# Altium schematic sheet SPK_P.SchDoc
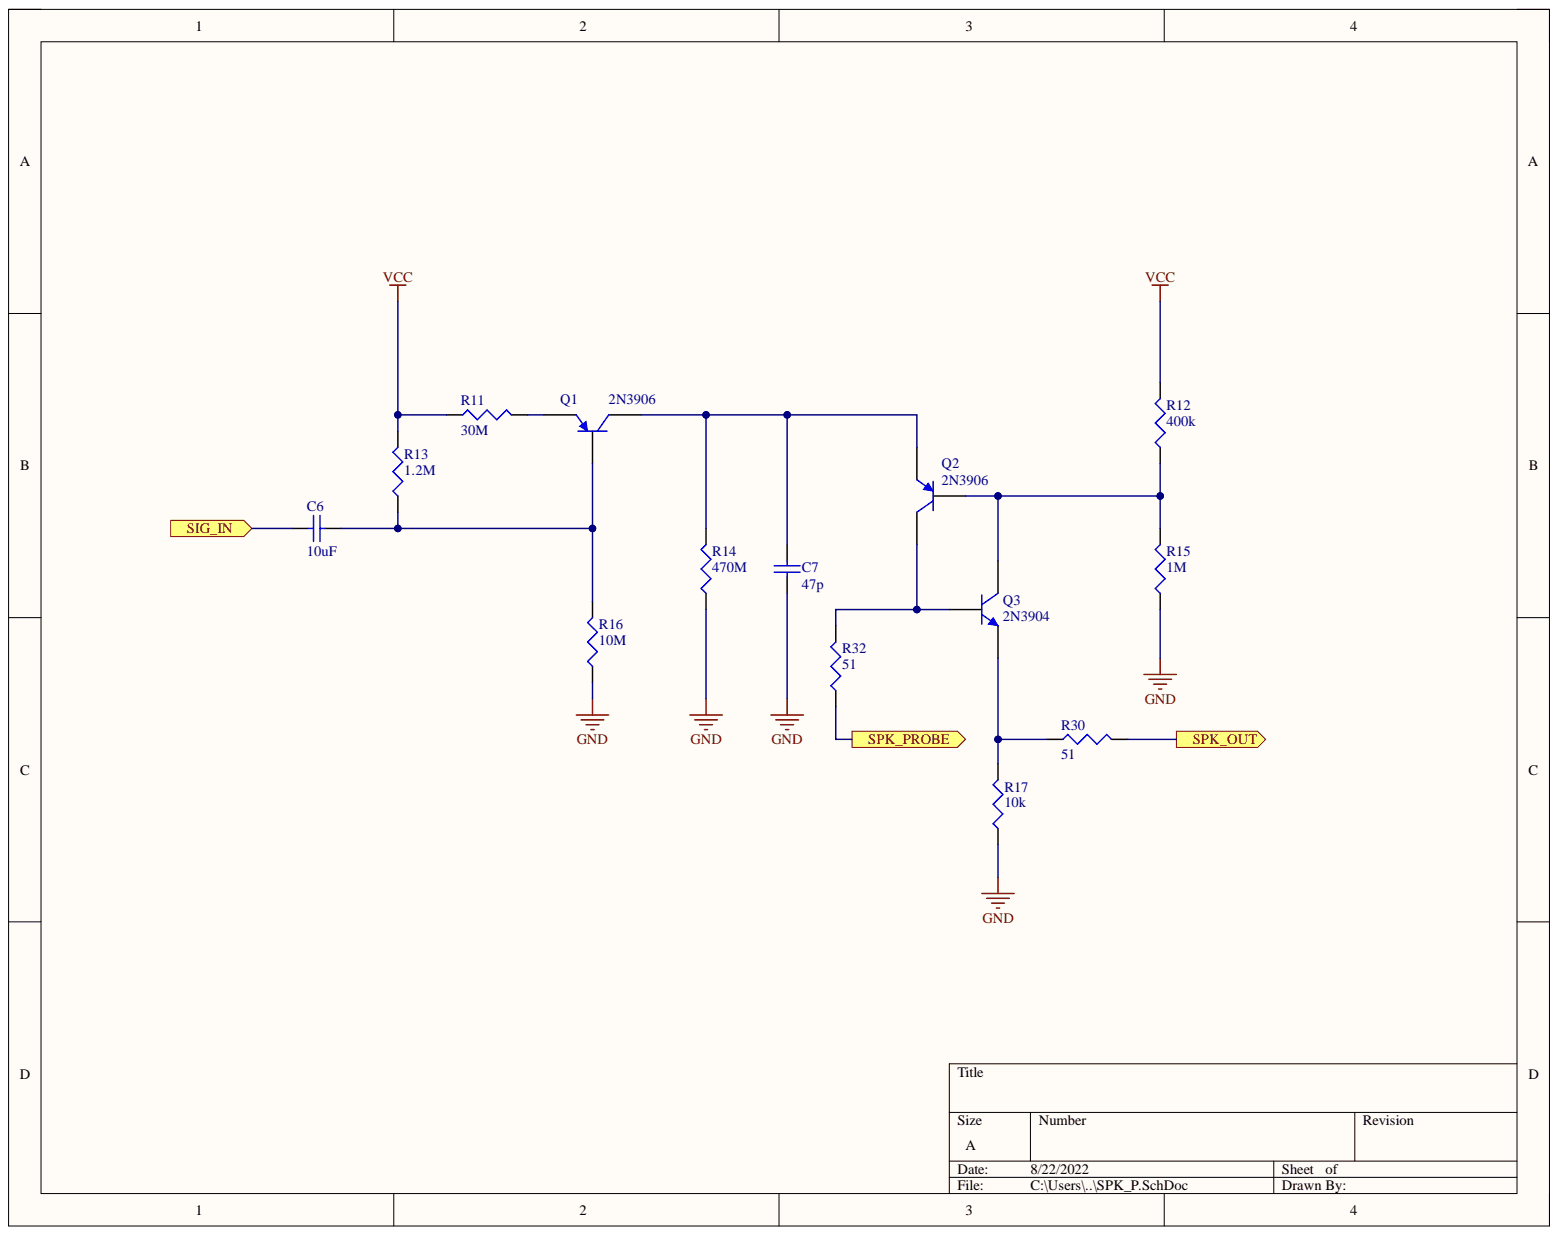
[diagram p0: SPK_P.SchDoc]

## Parts
C6  10uF  [SMD-0603]
C7  47p  [SMD-0603]
Q1  2N3906  [TO-92A]
Q2  2N3906  [TO-92A]
Q3  2N3904  [TO-92A]
R11  30M  [SMD-0603]
R12  400k  [SMD-0603]
R13  1.2M  [SMD-0603]
R14  470M  [SMD-0603]
R15  1M  [SMD-0603]
R16  10M  [SMD-0603]
R17  10k  [SMD-0603]
R30  51  [SMD-0603]
R32  51  [SMD-0603]

## Ports
SIG_IN, SPK_OUT, SPK_PROBE

## Nets
GND: C7.1 R14.1 R15.1 R16.1 R17.1
VCC: R11.1 R12.2 R13.2
SIG_IN: C6.1 ; port SIG_IN
SPK_OUT: R30.2 ; port SPK_OUT
SPK_PROBE: R32.1 ; port SPK_PROBE
NetC6_2: C6.2 Q1.2 R13.1 R16.2
NetC7_2: C7.2 Q1.3 Q2.1 R14.2
NetQ1_1: Q1.1 R11.2
NetQ2_2: Q2.2 Q3.3 R12.1 R15.2
NetQ2_3: Q2.3 Q3.2 R32.2
NetQ3_1: Q3.1 R17.2 R30.1

## Library data of the parts
C6 C7 = CAP0603: Ceramic Capacitor 0603; Component Kind: Standard; Library Name: LCR.SchLib
Q1 Q2 = 2N3906: PNP General Purpose Amplifier; Component Kind: Standard; ComponentLink1Description: Manufacturer Link; ComponentLink1URL: http://www.fairchildsemi.com/; ComponentLink2Description: Datasheet; ComponentLink2URL: http://www.fairchildsemi.com/ds/2N%2F2N3906.pdf; DatasheetVersion: 1997; LatestRevisionDate: 15-Jan-2003; LatestRevisionNote: PCB Footprint 'BCY-W3/D4.7' replaced; Library Name: Miscellaneous Devices.IntLib; PackageDescription: TO, Flat Index; 3 In-Line, Axial Leads; Body Dia. 4.5mm; Leads 0.51 x 0.48 mm (max); PackageReference: TO-92A; PackageVersion: Sep-1998; Published: 8-Jun-2000; Signal Integrity: 2N3906; Simulation: 2N3906; Publisher: Altium Limited
Q3 = 2N3904: NPN General Purpose Amplifier; Component Kind: Standard; ComponentLink1Description: Manufacturer Link; ComponentLink1URL: http://www.fairchildsemi.com/; ComponentLink2Description: Datasheet; ComponentLink2URL: http://www.fairchildsemi.com/ds/2N%2F2N3904.pdf; DatasheetVersion: 1997; LatestRevisionDate: 15-Jan-2003; LatestRevisionNote: PCB Footprint 'BCY-W3/D4.7' replaced; Library Name: Miscellaneous Devices.IntLib; PackageDescription: TO, Flat Index; 3 In-Line, Axial Leads; Body Dia. 4.5mm; Leads 0.51 x 0.48 mm (max); PackageReference: TO-92A; PackageVersion: Sep-1998; Published: 8-Jun-2000; Signal Integrity: 2N3904; Simulation: 2N3904; Publisher: Altium Limited
R11-R17 R30 R32 = RES0603: Resistor-0603; Component Kind: Standard; Library Name: LCR.SchLib
